# Altium schematic sheet PWM.SchDoc
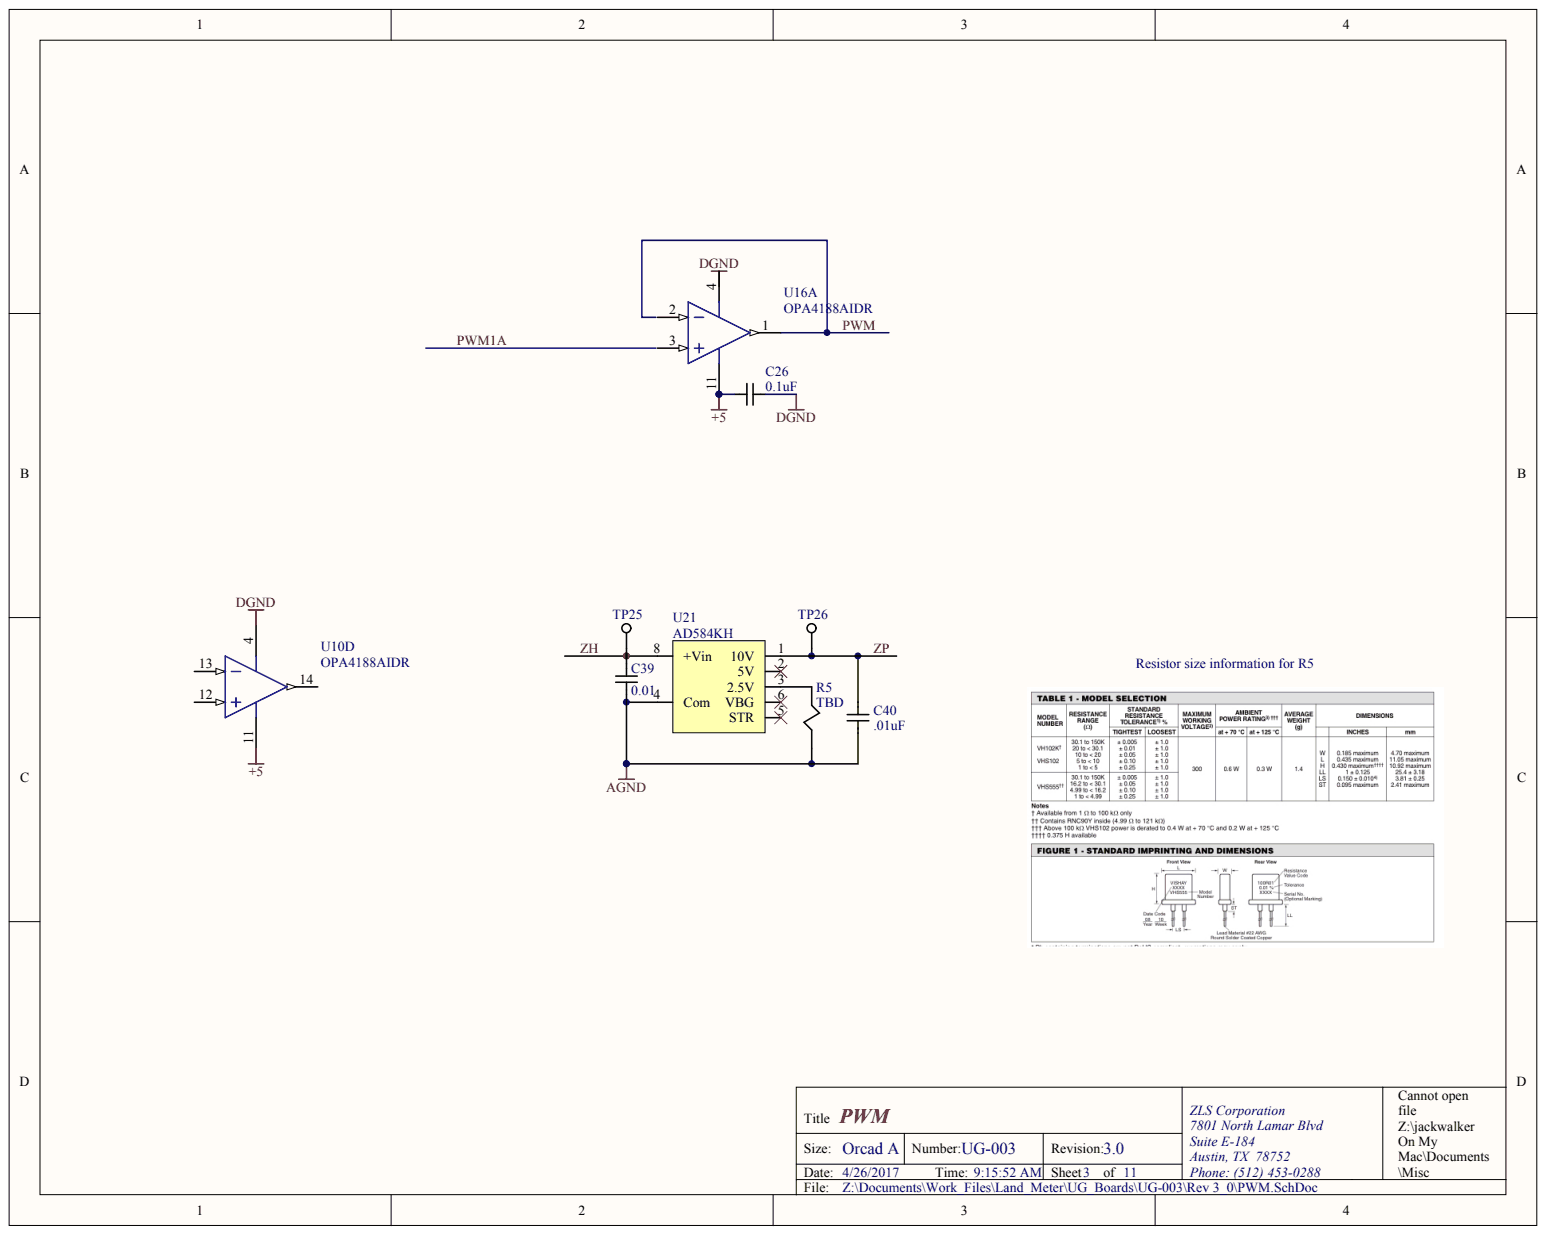
[diagram p0: PWM.SchDoc]

## Parts
C26  0.1uF
C39  0.01
C40  .01uF
R5  TBD
TP25  (no value)
TP26  (no value)
U10  OPA4188AIDR  (part D)
U16  OPA4188AIDR  (part A)
U21  AD584KH

## Nets
+5: C26.1 U10.11 U16.11
AGND: C39.2 C40.2 R5.1 U21.4(Com)
DGND: C26.2 U10.4
PWM: U16.1
ZH: C39.1 TP25.1 U21.8(+Vin)
ZP: C40.1 TP26.1 U21.1(10V)
NetR5_2: R5.2 U21.3(2.5V)
PWM1A:

Not connected: U10 12 13 14; U16 2 3 4; U21 2(5V) 5(STR) 6(VBG)

## Library data of the parts
C26 = (no symbol name): Manufacturer 1: Murata Electronics North America; Manufacturer Part Number 1: GRM21BR71E104KA01L; Description 1: CAP CER 0.1UF 25V X7R 0805; Category 1: Capacitors; Capacitance: 0.1µF; Tolerance: ±10%; Voltage - Rated: 25V; Temperature Coefficient: X7R; Supplier 1: Digi-Key; Supplier Part Number 1: 490-1673-1-ND; RoHS 1: RoHS Compliant; Stock 1: 897437; Pricing 1: 1=0.2, 10=0.145, 25=0.106, 50=0.0824, 100=0.0683, 250=0.05652, 500=0.04788, 1000=0.03964 (USD); Packaging: Cut Tape (CT); Part Status: Active; Operating Temperature: -55°C ~ 125°C; Features: -; Ratings: -; Applications: General Purpose; Mounting Type: Surface Mount, MLCC; Package / Case: 0805 (2012 Metric); Size / Dimension: 0.079" L x 0.049" W (2.00mm x 1.25mm); Height - Seated (Max): -; Thickness (Max): 0.053" (1.35mm); Lead Spacing: -; Lead Style: -; ComponentLink1URL: http://www.murata.com/~/media/webrenewal/support/library/catalog/products/capacitor/mlcc/c02e.ashx; ComponentLink1Description: http://www.murata.com/~/media/webrenewal/support/library/catalog/products/capacitor/mlcc/c02e.ashx
C39 = (no symbol name): Manufacturer 1: Murata Electronics North America; Manufacturer Part Number 1: GRM155R71H103KA88D; Description 1: CAP CER 10000PF 50V 10% X7R 0402; Category 1: Capacitors; Capacitance: 10000pF; Tolerance: ±10%; Voltage - Rated: 50V; Temperature Coefficient: X7R; Supplier 1: Digi-Key; Supplier Part Number 1: 490-4516-2-ND; RoHS 1: RoHS Compliant; Stock 1: 652600; Pricing 1: 10000=0.00372, 20000=0.00354, 30000=0.00336, 50000=0.00301, 100000=0.00243 (USD); Packaging: Tape & Reel (TR); Mounting Type: Surface Mount, MLCC; Operating Temperature: -55°C ~ 125°C; Applications: General Purpose; Ratings: -; Package / Case: 0402 (1005 Metric); Size / Dimension: 0.039" L x 0.020" W (1.00mm x 0.50mm); Height - Seated (Max): -; Thickness (Max): 0.022" (0.55mm); Lead Spacing: -; Features: -; Lead Style: -; ComponentLink1URL: http://www.murata.com/products/catalog/pdf/c02e.pdf; ComponentLink1Description: http://www.murata.com/products/catalog/pdf/c02e.pdf
C40 = (no symbol name): Manufacturer 1: Murata Electronics North America; Manufacturer Part Number 1: GRM216R71H103KA01D; Description 1: CAP CER 10000PF 50V X7R 0805; Category 1: Capacitors; Capacitance: 10000pF; Tolerance: ±10%; Voltage - Rated: 50V; Temperature Coefficient: X7R; Supplier 1: Digi-Key; Supplier Part Number 1: 490-1664-1-ND; RoHS 1: RoHS Compliant; Stock 1: 1003025; Pricing 1: 1=0.1, 10=0.072, 25=0.052, 50=0.0392, 100=0.032, 250=0.02672, 500=0.02282, 1000=0.01793 (USD); Packaging: Cut Tape (CT); Part Status: Active; Operating Temperature: -55°C ~ 125°C; Features: -; Ratings: -; Applications: General Purpose; Mounting Type: Surface Mount, MLCC; Package / Case: 0805 (2012 Metric); Size / Dimension: 0.079" L x 0.049" W (2.00mm x 1.25mm); Height - Seated (Max): -; Thickness (Max): 0.028" (0.70mm); Lead Spacing: -; Lead Style: -; ComponentLink1URL: http://www.murata.com/~/media/webrenewal/support/library/catalog/products/capacitor/mlcc/c02e.ashx; ComponentLink1Description: http://www.murata.com/~/media/webrenewal/support/library/catalog/products/capacitor/mlcc/c02e.ashx
R5 = (no symbol name): Description 1: RES TBD OHM 0.3W 1% RADIAL; Category 1: Resistors
U10 U16 = (no symbol name): Manufacturer: Texas Instruments; CMRR(Min)(dB): 120; Eco-Plan: Green (RoHS & no Sb/Br); GBW(Typ)(MHz): 2; Iq per channel(Max)(mA): 0.475; Number of Channels: 4; Offset Drift(Typ)(uV/C): 0.03; Packing: LARGE T&R; Rating: Catalog; Slew Rate(Typ)(V/us): 0.8; SubFamily: High Supply Voltage Amplifier (>=30V), Low Noise Amp (<=10nV/rtHz), Low Offset Voltage Amplifier (<=500uV), Low Power Amp (<=500uA), Zero Drift Precision Amplifier; Total Supply Voltage(Max)(+5V is 5, +/-5V is 10): 36; DatasheetVersion: SBOS641B; PackageVersion: 4040047-5/M, 06/2011; PackageReference: D14; PackageDescription: 14-Pin Plastic Small Outline, Body 8.65 x 3.9 mm, Pitch 1.27 mm; Supplier 1: Digi-Key; Supplier Part Number 1: 296-30574-2-ND; Supplier 2: Digi-Key; Supplier Part Number 2: 296-30574-1-ND; Supplier 3: Digi-Key; Supplier Part Number 3: 296-30574-6-ND; Supplier 4: Newark; Supplier Part Number 4: 27W9606; Supplier 5: Mouser; Supplier Part Number 5: 595-OPA4188AIDR; Total Supply Voltage(Min)(+5V is 5, +/-5V is 10): 4; Mounting Technology: Surface Mount; Code_JEDEC: MS-012; PartNumber: OPA4188AIDR; ComponentLink1Description: Manufacturer URL; ComponentLink1URL: http://www.ti.com/; ComponentLink2Description: Datasheet; ComponentLink2URL: http://www.ti.com/lit/ds/symlink/opa4188.pdf; ComponentLink3Description: Package Specification; ComponentLink3URL: http://www.ti.com/lit/ml/mpds177g/mpds177g.pdf
U21 = (no symbol name): Manufacturer 1: Analog Devices Inc.; Description 1: IC VREF SERIES PROG TO99-8; Category 1: Integrated Circuits (ICs); Reference Type: Series; Output Type: Programmable; Voltage - Output (Min/Fixed): 2.5V, 5V, 7.5V, 10V; Current - Output: 10mA; Tolerance: ±0.1%; Temperature Coefficient: 15ppm/°C; Noise - 0.1Hz to 10Hz: 50µVp-p; Voltage - Input: 4.5 V ~ 30 V; Current - Supply: 1mA; Supplier 1: Digi-Key; Supplier Part Number 1: AD584KH-ND; Manufacturer Part Number 1: AD584KH; RoHS 1: RoHS non-compliant; Stock 1: 133; Pricing 1: 1=30.28, 10=27.928, 100=23.8488 (USD); Packaging: Bulk; Part Status: Active; Voltage - Output (Max): -; Noise - 10Hz to 10kHz: -; Current - Cathode: -; Operating Temperature: 0°C ~ 70°C (TA); Mounting Type: Through Hole; Package / Case: TO-99-8 Metal Can; Supplier Device Package: TO-99-8; ComponentLink1URL: http://www.analog.com/media/en/technical-documentation/data-sheets/AD584.pdf; ComponentLink1Description: http://www.analog.com/media/en/technical-documentation/data-sheets/AD584.pdf
